# Altium schematic sheet ADC_Unity_Gain.SchDoc
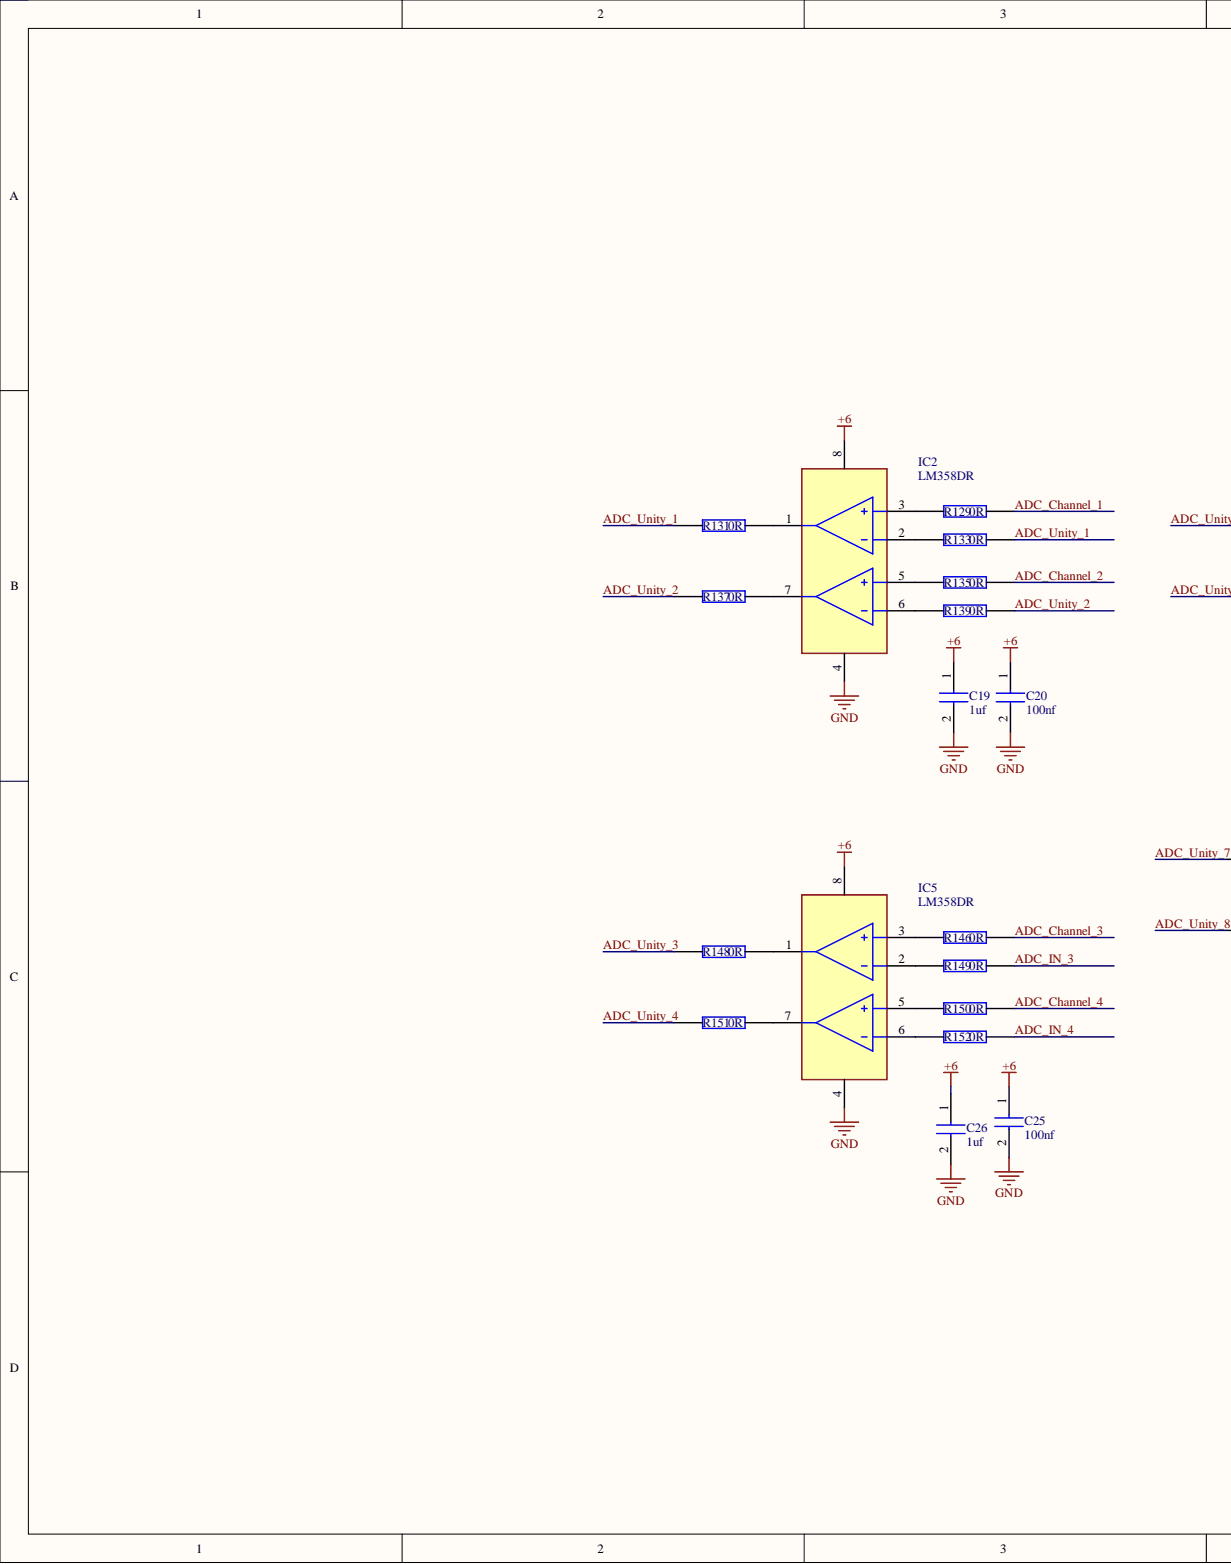
[diagram p0: ADC_Unity_Gain.SchDoc, left]
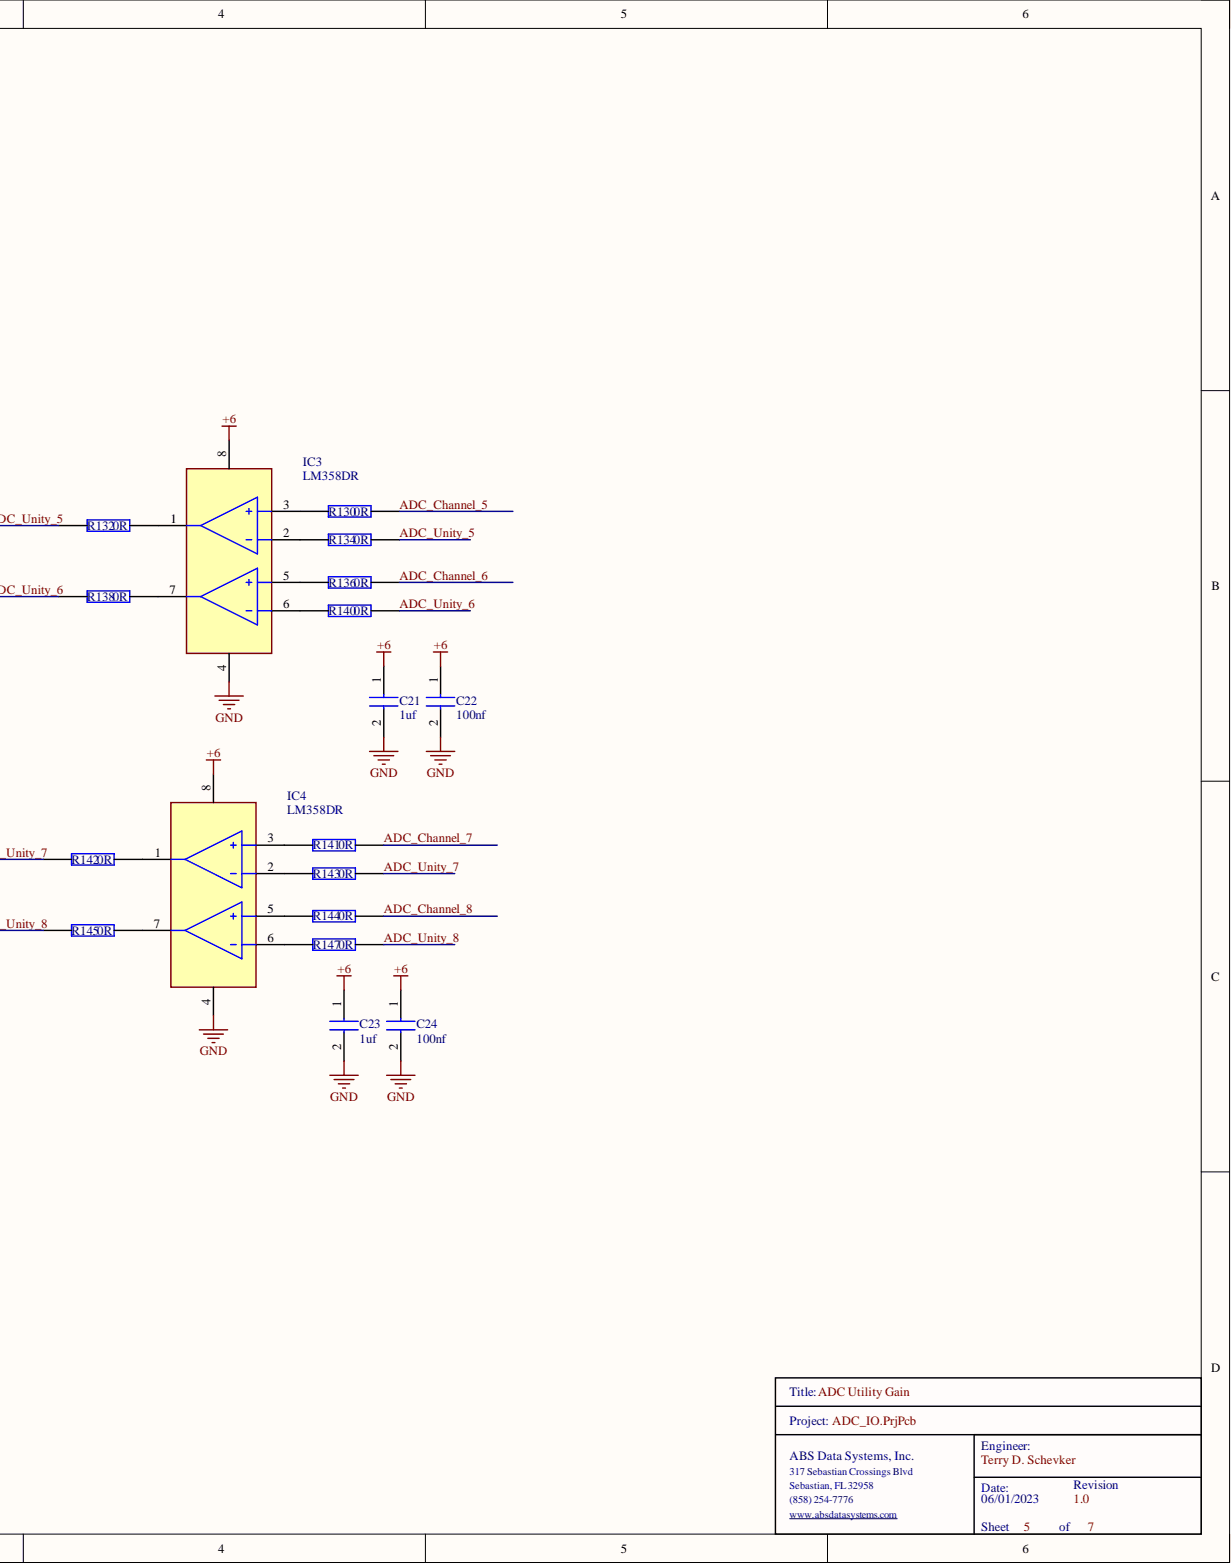
[diagram p1: ADC_Unity_Gain.SchDoc, right]

## Parts
C19  1uf  [0603]
C20  100nf  [0603]
C21  1uf  [0603]
C22  100nf  [0603]
C23  1uf  [0603]
C24  100nf  [0603]
C25  100nf  [0603]
C26  1uf  [0603]
IC2  LM358DR  [SOIC127P600X175-8N]
IC3  LM358DR  [SOIC127P600X175-8N]
IC4  LM358DR  [SOIC127P600X175-8N]
IC5  LM358DR  [SOIC127P600X175-8N]
R129  0R  [0603]  (value not drawn)
R130  0R  [0603]  (value not drawn)
R131  0R  [0603]  (value not drawn)
R132  0R  [0603]  (value not drawn)
R133  0R  [0603]  (value not drawn)
R134  0R  [0603]  (value not drawn)
R135  0R  [0603]  (value not drawn)
R136  0R  [0603]  (value not drawn)
R137  0R  [0603]  (value not drawn)
R138  0R  [0603]  (value not drawn)
R139  0R  [0603]  (value not drawn)
R140  0R  [0603]  (value not drawn)
R141  0R  [0603]  (value not drawn)
R142  0R  [0603]  (value not drawn)
R143  0R  [0603]  (value not drawn)
R144  0R  [0603]  (value not drawn)
R145  0R  [0603]  (value not drawn)
R146  0R  [0603]  (value not drawn)
R147  0R  [0603]  (value not drawn)
R148  0R  [0603]  (value not drawn)
R149  0R  [0603]  (value not drawn)
R150  0R  [0603]  (value not drawn)
R151  0R  [0603]  (value not drawn)
R152  0R  [0603]  (value not drawn)

## Nets
+6: C19.1 C20.1 C21.1 C22.1 C23.1 C24.1 C25.1 C26.1 IC2.8 IC3.8 IC4.8 IC5.8
GND: C19.2 C20.2 C21.2 C22.2 C23.2 C24.2 C25.2 C26.2 IC2.4 IC3.4 IC4.4 IC5.4
ADC_Channel_1: R129.2
ADC_Channel_2: R135.2
ADC_Channel_3: R146.2
ADC_Channel_4: R150.2
ADC_Channel_5: R130.2
ADC_Channel_6: R136.2
ADC_Channel_7: R141.2
ADC_Channel_8: R144.2
ADC_IN_3: R149.2
ADC_IN_4: R152.2
ADC_Unity_1: R131.1 R133.2
ADC_Unity_2: R137.1 R139.2
ADC_Unity_3: R148.1
ADC_Unity_4: R151.1
ADC_Unity_5: R132.1 R134.2
ADC_Unity_6: R138.1 R140.2
ADC_Unity_7: R142.1 R143.2
ADC_Unity_8: R145.1 R147.2
NetIC2_1: IC2.1 R131.2
NetIC2_2: IC2.2 R133.1
NetIC2_3: IC2.3 R129.1
NetIC2_5: IC2.5 R135.1
NetIC2_6: IC2.6 R139.1
NetIC2_7: IC2.7 R137.2
NetIC3_1: IC3.1 R132.2
NetIC3_2: IC3.2 R134.1
NetIC3_3: IC3.3 R130.1
NetIC3_5: IC3.5 R136.1
NetIC3_6: IC3.6 R140.1
NetIC3_7: IC3.7 R138.2
NetIC4_1: IC4.1 R142.2
NetIC4_2: IC4.2 R143.1
NetIC4_3: IC4.3 R141.1
NetIC4_5: IC4.5 R144.1
NetIC4_6: IC4.6 R147.1
NetIC4_7: IC4.7 R145.2
NetIC5_1: IC5.1 R148.2
NetIC5_2: IC5.2 R149.1
NetIC5_3: IC5.3 R146.1
NetIC5_5: IC5.5 R150.1
NetIC5_6: IC5.6 R152.1
NetIC5_7: IC5.7 R151.2

## Library data of the parts
C19 C21 C23 C26 = CL10B105KA8NNNL: Samsung Electro-Mechanics 0603 1uf; Component Kind: Standard; Library Name: Capacitors.SchLib
C20 C22 C24 C25 = CL10B104JB8NNNC: Samsung Electro-Mechanics 0603 100nf; Component Kind: Standard; Library Name: Capacitors.SchLib
IC2-IC5 = LM358DR: Integrated Circuit; Manufacturer_Name: Texas Instruments; Arrow Part Number: LM358DR; Arrow Price/Stock: https://www.arrow.com/en/products/lm358dr/texas-instruments?region=nac; Component Kind: Standard; Datasheet Link: https://www.ti.com/lit/ds/symlink/lm358.pdf?ts=1593170624750&ref_url=https%253A%252F%252Fwww.ti.com%252Fstore%252Fti%252Fen%252Fp%252Fproduct%252F%253Fp%253DLM358DR%2526keyMatch%253DLM358DR%2526tisearch%253DSearch-EN-everything%2526usecase%253DOPN; Height: 1.75mm; Manufacturer_Part_Number: LM358DR; Mouser Part Number: 595-LM358DR; Mouser Price/Stock: https://www.mouser.co.uk/ProductDetail/Texas-Instruments/LM358DR?qs=Zu35EjizYSSY6pJ37yjmHA%3D%3D; Library Name: SemiConductors.SchLib
R129-R152 = RC0603JR-070RL: YAGEO 0603 0 ohm; Manufacturer_Name: KEMET; Geometry.Height: 0.55mm; Component Kind: Standard; Datasheet Link: https://datasheet.datasheetarchive.com/originals/distributors/Datasheets_SAMA/902f9e387b938f871d31120f5fc1d65e.pdf; Library Name: Resistors.SchLib; Manufacturer_Part_Number: RC0603JR-070RL; Mouser Part Number: 603-RC0603JR-070RL; Mouser Price/Stock: https://www.mouser.co.uk/ProductDetail/YAGEO/RC0603JR-070RL?qs=2cAdsCoAWRGWyPcuXgGiXQ%3D%3D
